# Altium schematic sheet I2C.SchDoc(I2C)
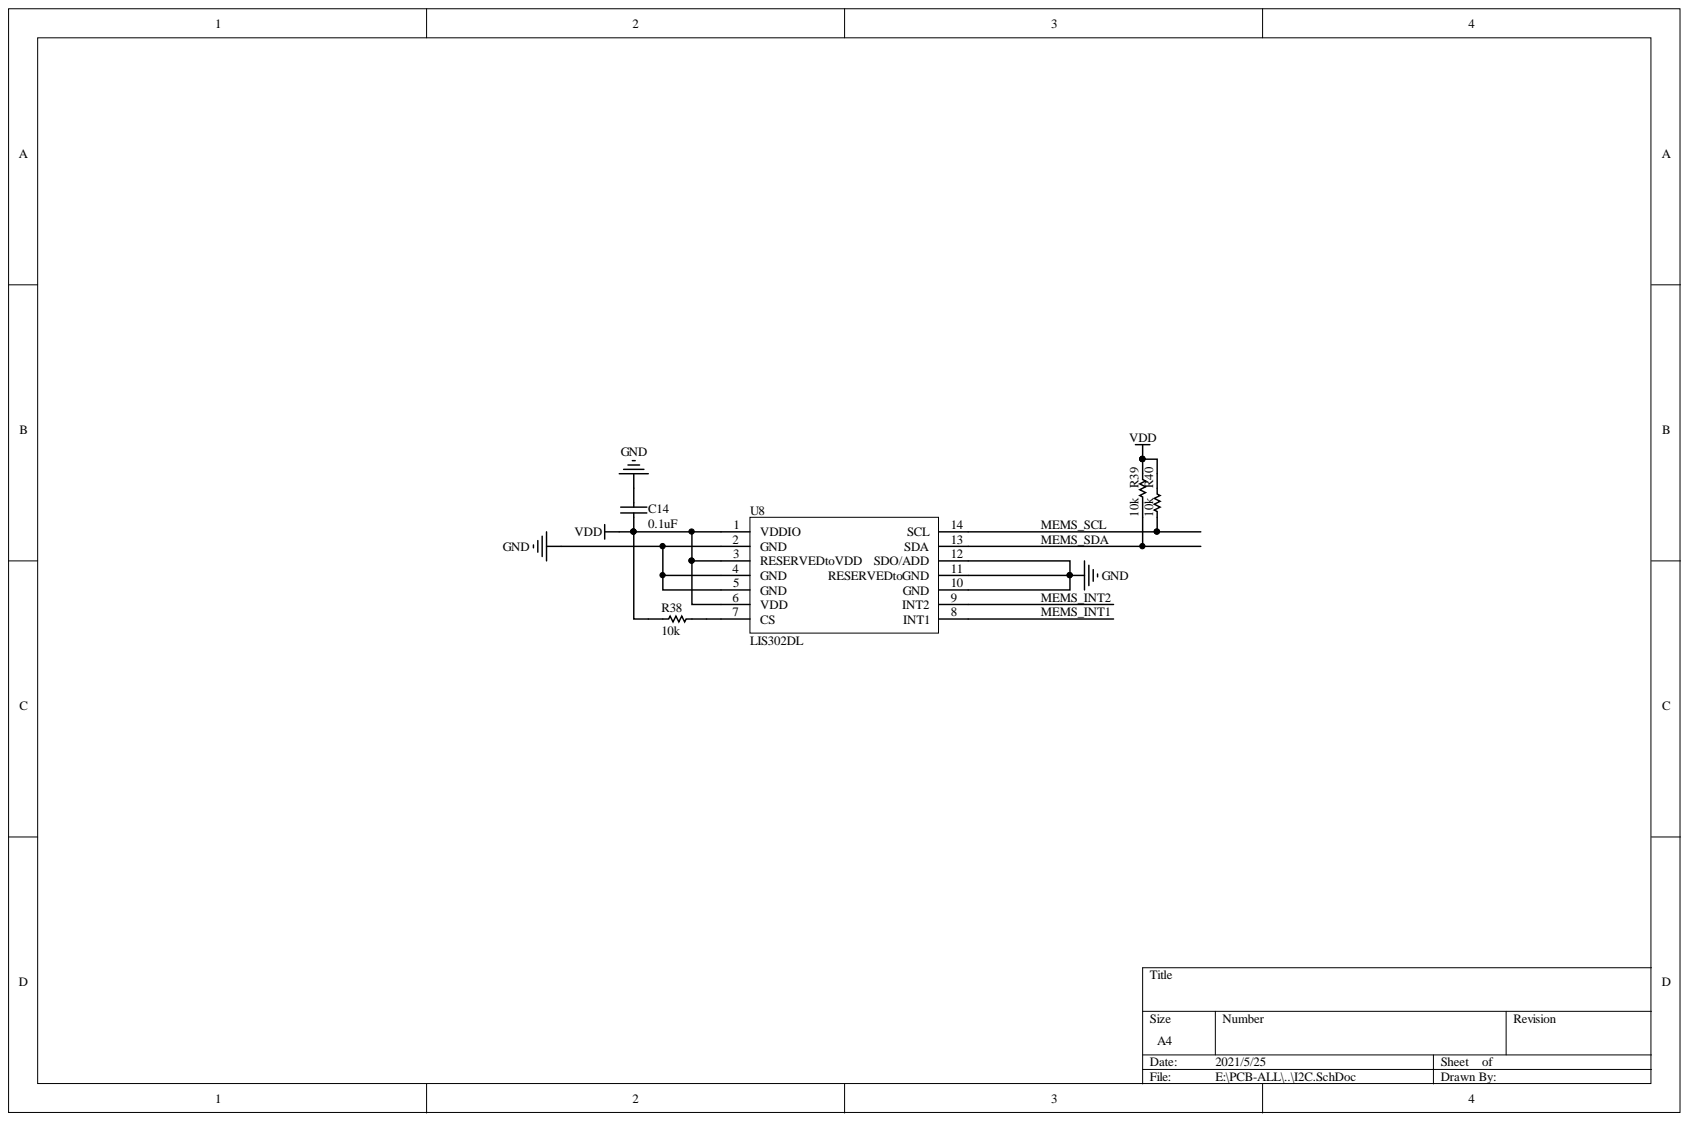
[diagram p0: I2C.SchDoc]

## Parts
C14  0.1uF  [C0805]
R38  10k  [R0805]
R39  10k  [R0805]
R40  10k  [R0805]
U8  LIS302DL  [LIS302DL]

## Nets
GND: C14.2 U8.2(GND) U8.4(GND) U8.5(GND) U8.10(GND) U8.11(RESERVEDtoGND) U8.12(SDO/ADD)
VDD: C14.1 R38.1 R39.1 R40.1 U8.1(VDDIO) U8.3(RESERVEDtoVDD) U8.6(VDD)
MEMS_INT1: U8.8(INT1)
MEMS_INT2: U8.9(INT2)
MEMS_SCL: R40.2 U8.14(SCL)
MEMS_SDA: R39.2 U8.13(SDA)
NetR38_2: R38.2 U8.7(CS)

## Library data of the parts
C14 = CAP: Capacitor; 中文名称: 贴片电容; Component Kind: Standard; Library Name: 2014.SchLib
R38-R40 = Res1: Resistor; Value: 1K; Component Kind: Standard; Library Name: 2014.SchLib; Published: 8-Jun-2000; Revision: July-2002: Re-released for DXP Platform.; Signal Integrity: Res; Simulation: RESISTOR; Publisher: Altium Limited
U8 = LIS302DL: Component Kind: Standard; Library Name: 2014.SchLib
